# Altium schematic sheet ch55x.SchDoc(ch55x)
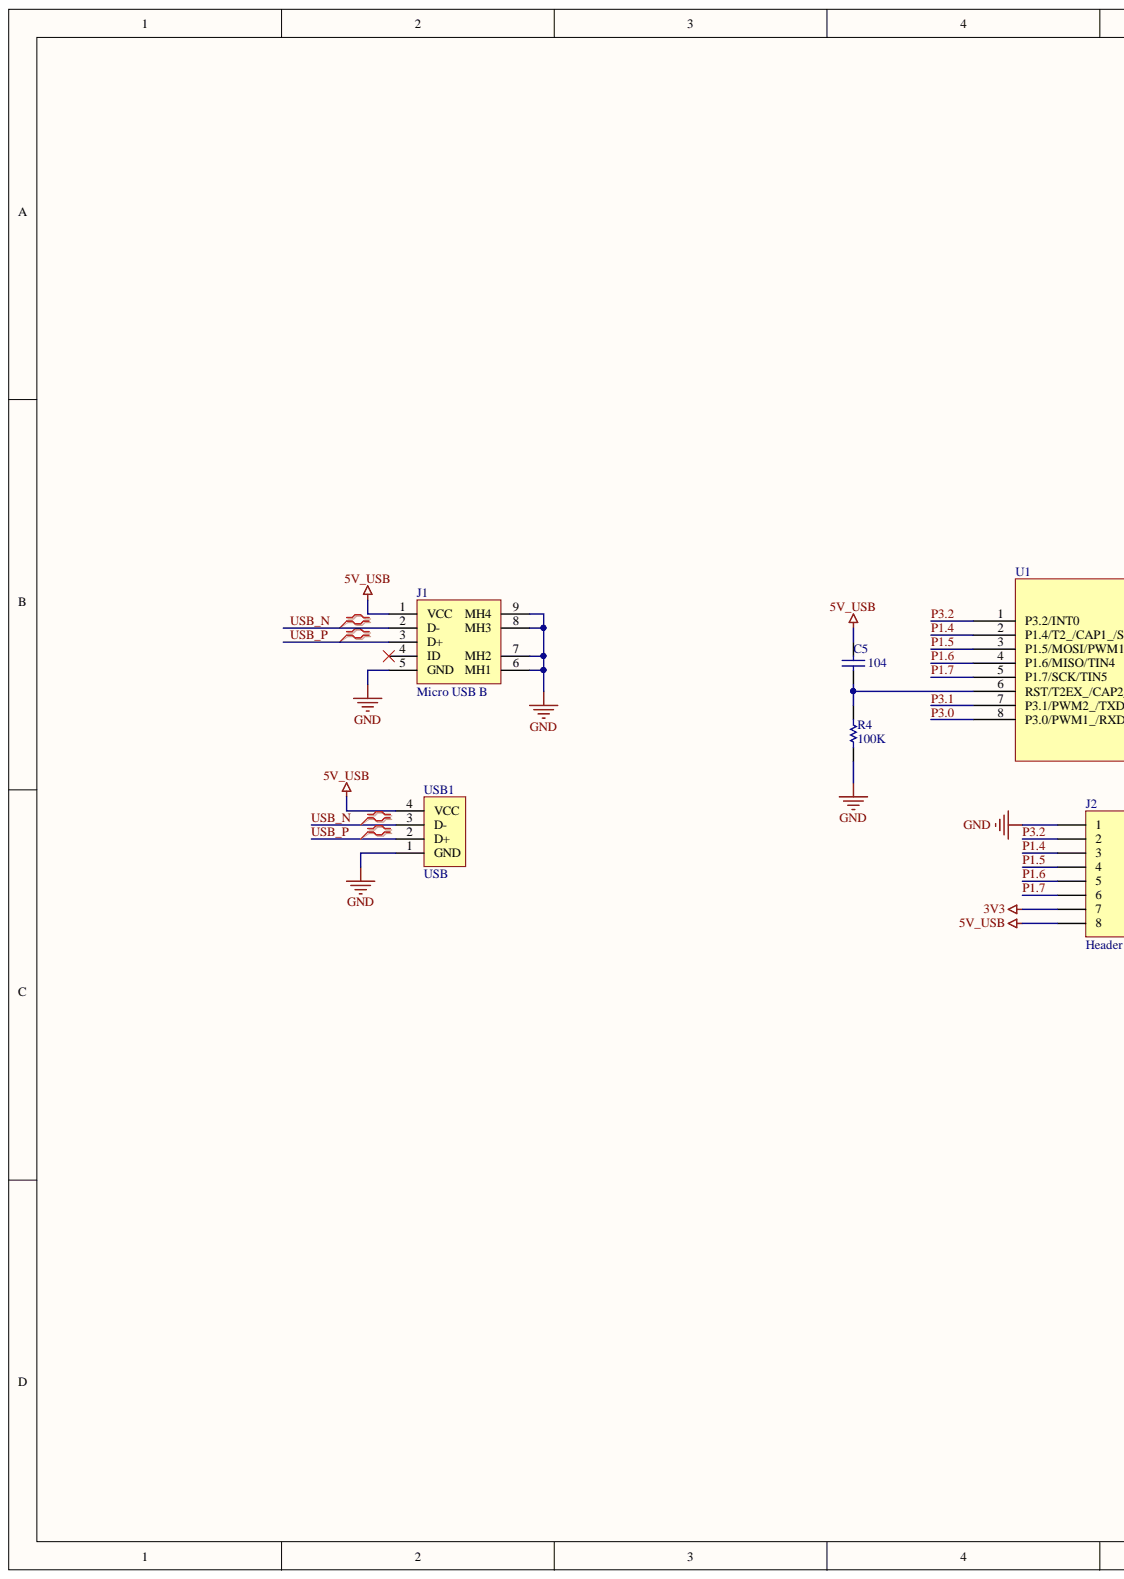
[diagram p0: ch55x.SchDoc, left]
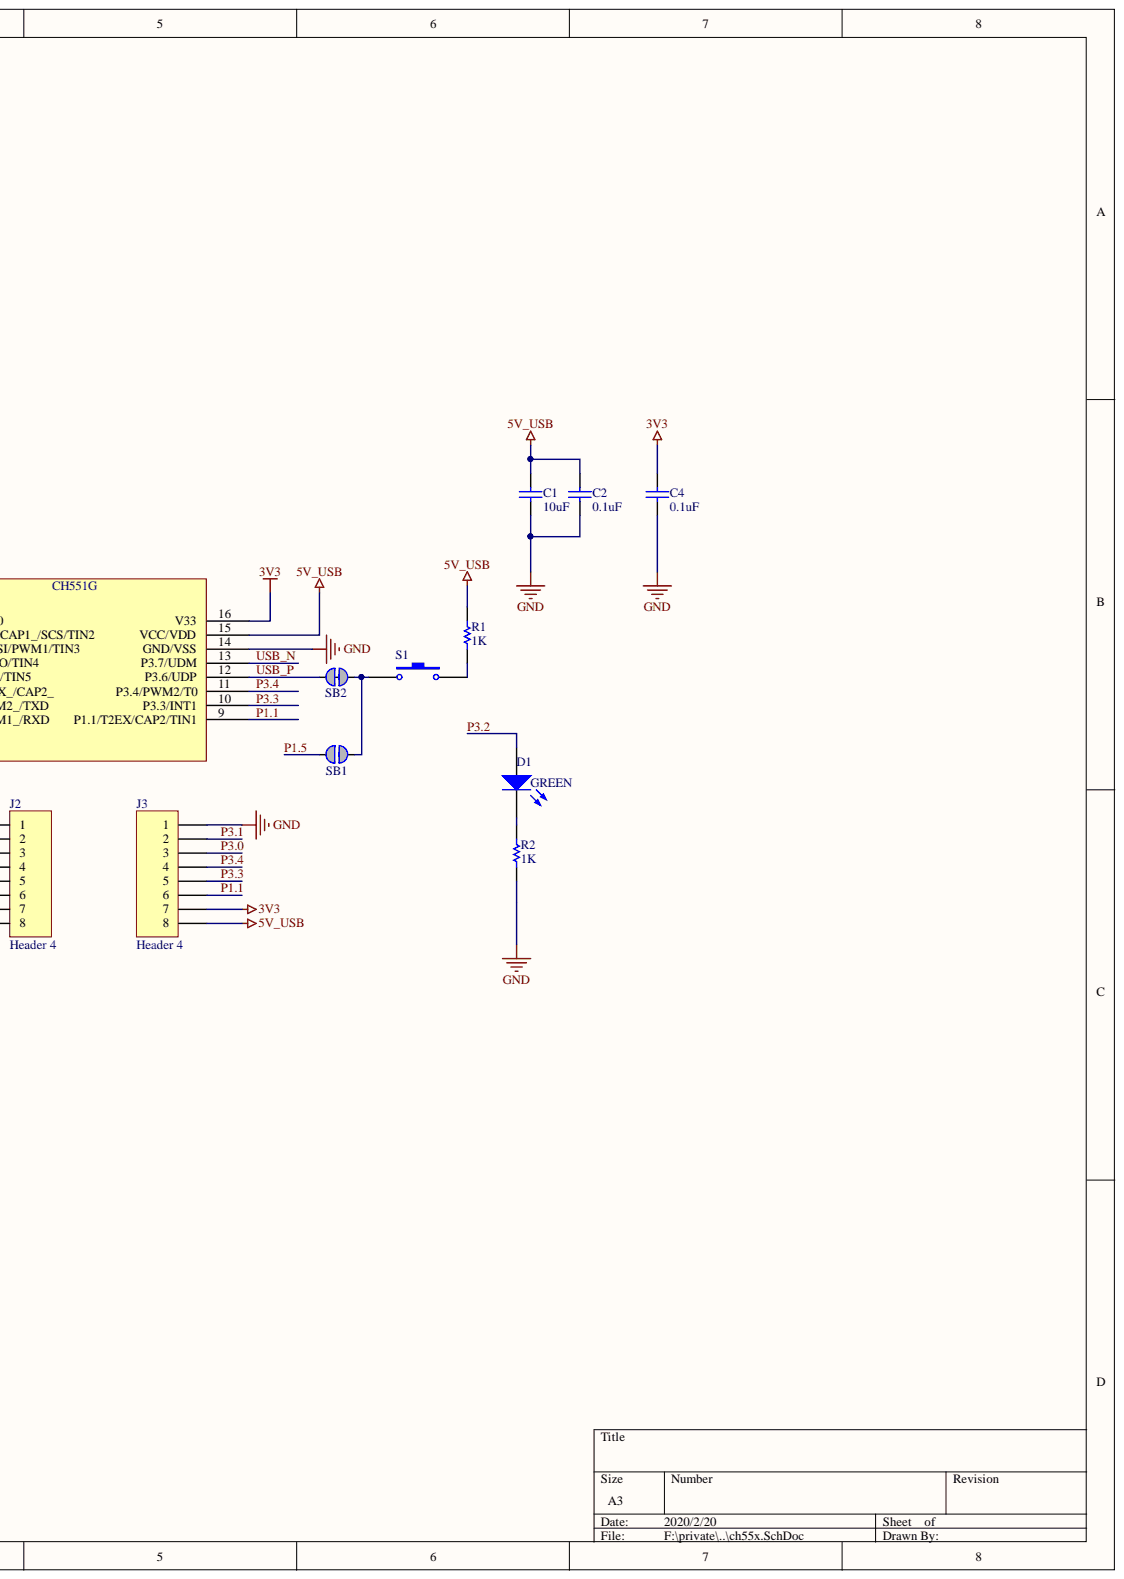
[diagram p1: ch55x.SchDoc, right]

## Parts
C1  value 10uF  [0603_C]  (hidden comment: C0603)
C2  value 0.1uF  [0603_C]  (hidden comment: C0603)
C4  value 0.1uF  [0603_C]  (hidden comment: C0603)
C5  104  [0603_C]
D1  GREEN  [0603_LED]
J1  Micro USB B  [MICRO_USB]
J2  Header 4  [HDR2.54-LI-8P]
J3  Header 4  [HDR2.54-LI-8P]
R1  value 1K  [0603_R]  (hidden comment: R0603)
R2  value 1K  [0603_R]  (hidden comment: R0603)
R4  value 100K  [0603_R]  (hidden comment: R0603)
S1  SW-PB  [SMT_SW_PTS_820]  (value not drawn)
SB1  Solderbridge  [Solderbridge]  (value not drawn)  (no BOM)
SB2  Solderbridge  [Solderbridge_Shorted]  (value not drawn)
U1  CH551G  [SOP16N_M]
USB1  USB  [USB_A_G]

## Nets
3V3: C4.2 J2.7 J3.7 U1.16(V33)
5V_USB: C1.2 C2.2 C5.2 J1.1(VCC) J2.8 J3.8 R1.1 U1.15(VCC/VDD) USB1.4(VCC)
GND: C1.1 C2.1 C4.1 J1.5(GND) J1.6(MH1) J1.7(MH2) J1.8(MH3) J1.9(MH4) J2.1 J3.1 R2.1 R4.1 U1.14(GND/VSS) USB1.1(GND)
P1.1: J3.6 U1.9(P1.1/T2EX/CAP2/TIN1)
P1.4: J2.3 U1.2(P1.4/T2_/CAP1_/SCS/TIN2)
P1.5: J2.4 SB1.1 U1.3(P1.5/MOSI/PWM1/TIN3)
P1.6: J2.5 U1.4(P1.6/MISO/TIN4)
P1.7: J2.6 U1.5(P1.7/SCK/TIN5)
P3.0: J3.3 U1.8(P3.0/PWM1_/RXD)
P3.1: J3.2 U1.7(P3.1/PWM2_/TXD)
P3.2: D1.1 J2.2 U1.1(P3.2/INT0)
P3.3: J3.5 U1.10(P3.3/INT1)
P3.4: J3.4 U1.11(P3.4/PWM2/T0)
USB_N: J1.2(D-) U1.13(P3.7/UDM) USB1.3(D-)
USB_P: J1.3(D+) SB2.2 U1.12(P3.6/UDP) USB1.2(D+)
NetC5_1: C5.1 R4.2 U1.6(RST/T2EX_/CAP2_)
NetD1_2: D1.2 R2.2
NetR1_2: R1.2 S1.2
NetS1_1: S1.1 SB1.2 SB2.1

Not connected: J1 4(ID)

## Library data of the parts
C1 C2 C4 = C0603: Capacitor; Simulation: CAP; Signal Integrity: Cap; Published: 8-Jun-2000; PackageReference: RAD-0.3; PackageDescription: Radial Cap, Thru-Hole; 2 Leads; 0.3 in Pin Spacing; Library Name: MYLIB.IntLib; LatestRevisionNote: Re-released for DXP Platform.; LatestRevisionDate: 17-Jul-2002; Component Kind: Standard; Publisher: Altium Limited
C5 = CAP: Capacitor; value: 0.1uF; Component Kind: Standard; Library Name: Cheetie Integrated Library 2017.IntLib
D1 = D0603: Typical RED, GREEN, YELLOW, AMBER GaAs LED; Simulation: LED2; Published: 8-Jun-2000; PackageVersion: Feb-2002; PackageReference: 3.2X1.6X1.1; PackageDescription: SM LED; 2 Flat Leads; Library Name: MYLIB.IntLib; LatestRevisionNote: Re-released for DXP Platform.; LatestRevisionDate: 17-Jul-2002; Component Kind: Standard; Publisher: Altium Limited
J2 J3 = Header 8: Header, 4-Pin; Signal Integrity: Connector; Library Name: ch55x.SCHLIB; LatestRevisionNote: Re-released for DXP Platform.; LatestRevisionDate: 17-Jul-2002; Component Kind: Standard; Publisher: Altium Limited
R1 R2 R4 = R0603: Resistor; Simulation: RESISTOR; Signal Integrity: Res; Published: 8-Jun-2000; PackageReference: AXIAL-0.3; PackageDescription: Axial Device, Thru-Hole; 2 Leads; 0.3 in Pin Spacing; Library Name: MYLIB.IntLib; LatestRevisionNote: Re-released for DXP Platform.; LatestRevisionDate: 17-Jul-2002; Component Kind: Standard; Publisher: Altium Limited
S1 = SW-PB: Switch; Published: 24-Mar-1999; PackageReference: SPST-2; PackageDescription: Switch; 2 Leads; Library Name: Miscellaneous Devices.IntLib; LatestRevisionNote: Re-released for DXP Platform.; LatestRevisionDate: 17-Jul-2002; Component Kind: Standard; Publisher: Altium Limited
SB1 = (no symbol name): Artikkelnr Simpro: N.A.; Value: Solderbridge; Published: 2009-12-14; Pin Count: 2; Part Number: Solderbridge; Library Name: NODEMCU_ESP12.SCHLIB; LatestRevisionDate: 2009-12-14; Footprint Doc: Solderbridge; Description: Solderbridge; Artikkelnr Noca: N.A.; Publisher: Nordic Semiconductor
SB2 = Solderbridge Shorted: Shorted Solderbridge; Database Table Name: Connectors$; Artikkelnr Simpro: N.A.; Value: Solderbridge; Published: 2012-08-07; Pin Count: 2; Part Number: Solderbridge Shorted; Library Name: Nordic Passive DB.DbLib; LatestRevisionDate: 2012-08-07; Footprint Doc: Solderbridge_Shorted; Component Kind: Net Tie; Artikkelnr Noca: N.A.; Publisher: Nordic Semiconductor
USB1 = USB_G: Component Kind: Standard; Library Name: nanoDAP.SCHLIB
J1 = Micro USB B: Library Name: nanoSTM32.SCHLIB; Component Kind: Standard
U1 = CH551G: Library Name: ch55x.SCHLIB; Component Kind: Standard
